# Altium schematic sheet Sheriff-Stern.SchDoc(Sheriff-Stern)
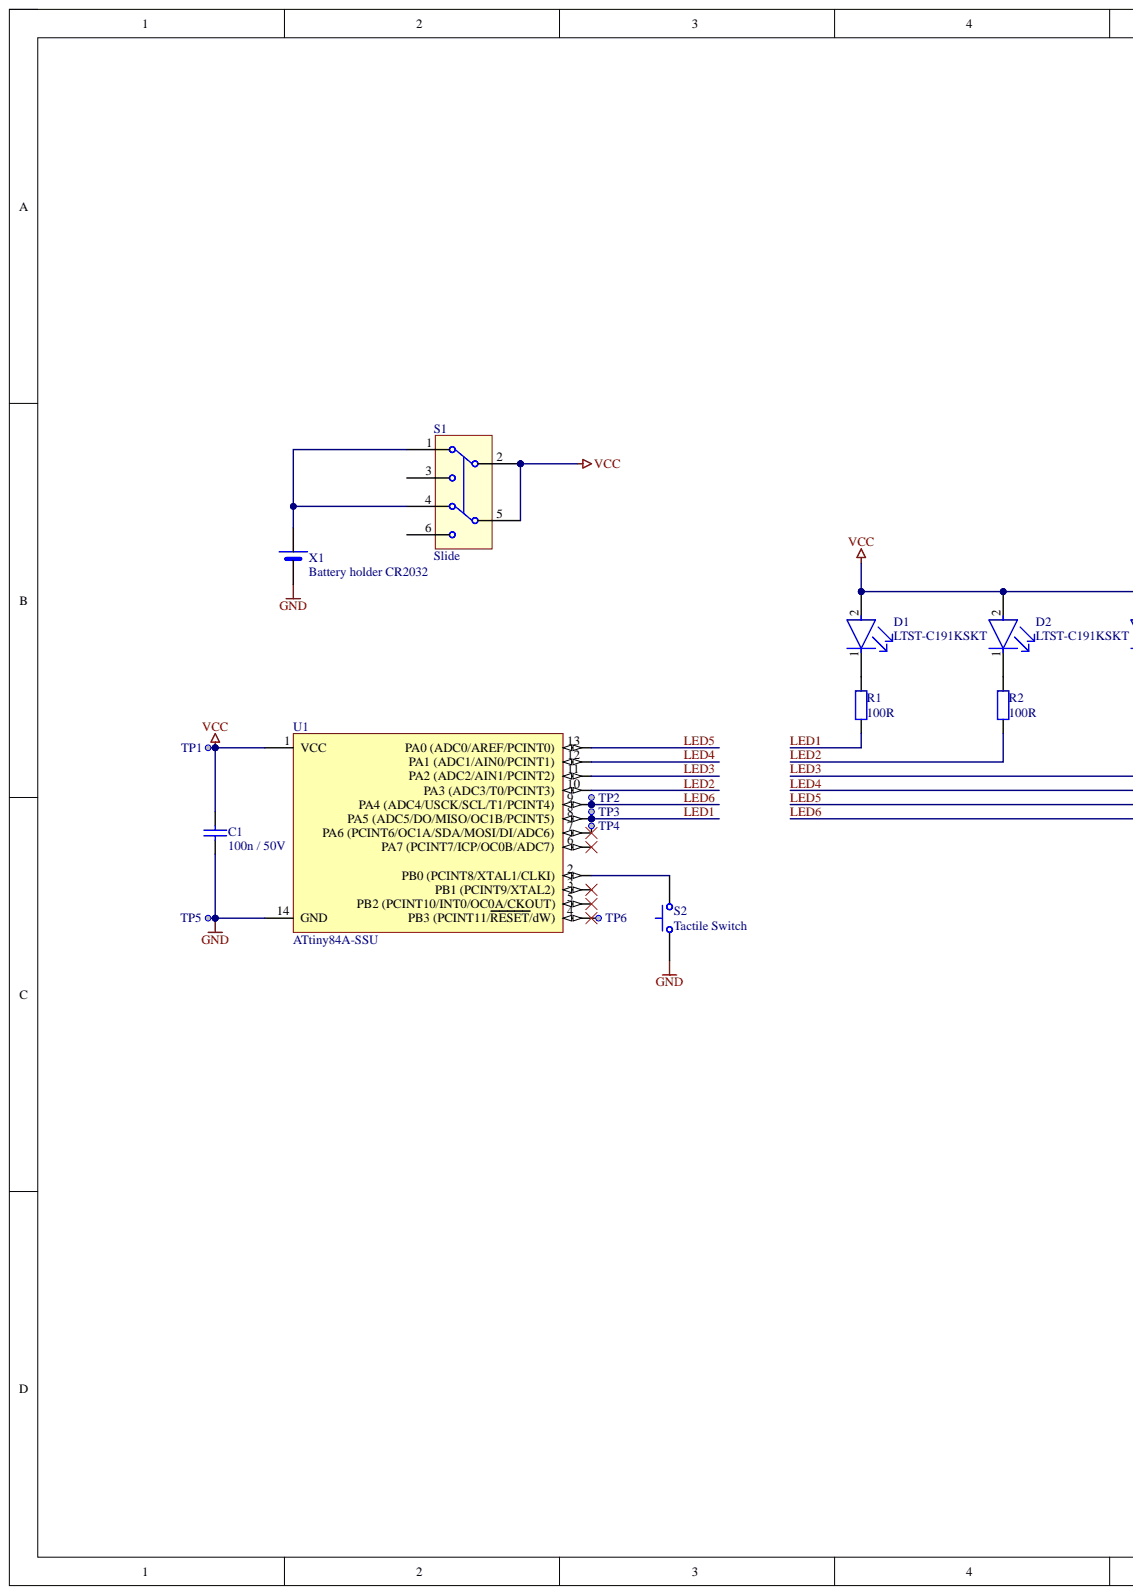
[diagram p0: Sheriff-Stern.SchDoc, left]
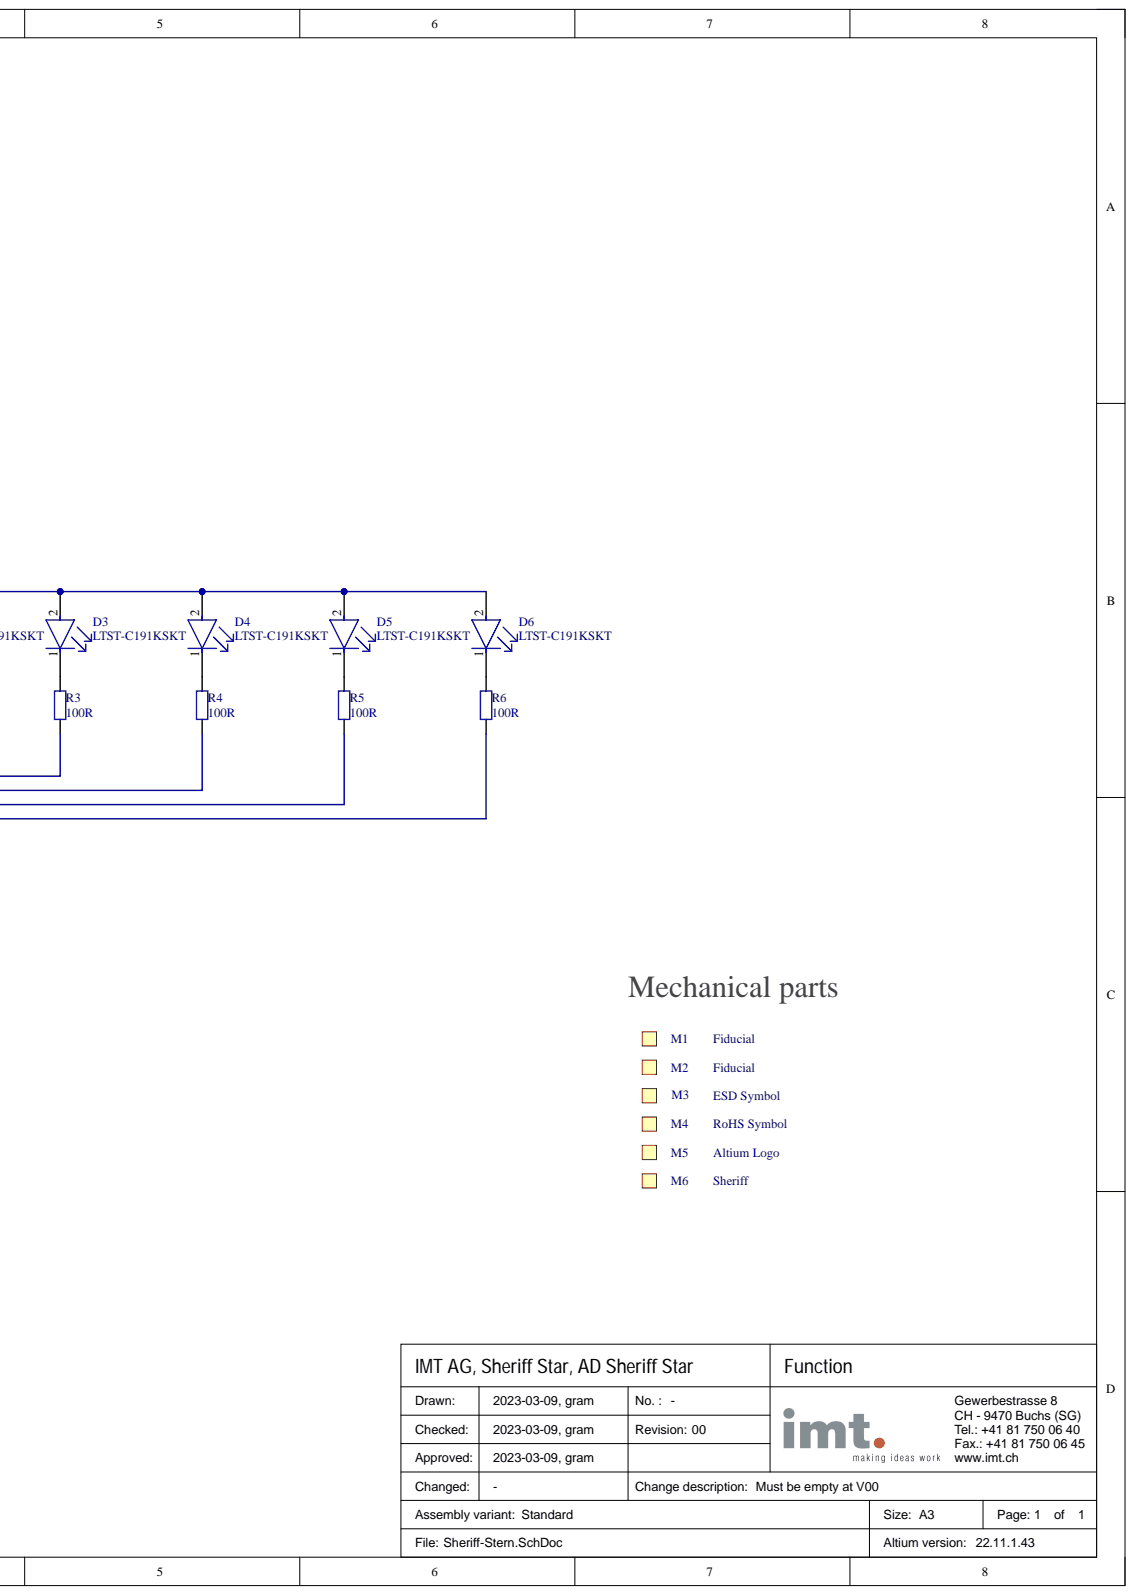
[diagram p1: Sheriff-Stern.SchDoc, right]

## Parts
C1  11011700  [SMT_CAP_0603_09H]  (value not drawn)
D1  LTST-C191KSKT  [FP-LTST-C191KSKT-MFG]
D2  LTST-C191KSKT  [FP-LTST-C191KSKT-MFG]
D3  LTST-C191KSKT  [FP-LTST-C191KSKT-MFG]
D4  LTST-C191KSKT  [FP-LTST-C191KSKT-MFG]
D5  LTST-C191KSKT  [FP-LTST-C191KSKT-MFG]
D6  LTST-C191KSKT  [FP-LTST-C191KSKT-MFG]
M1  11028600  [MECH_FIDUCIAL_2MM]  (value not drawn)  (no BOM)
M2  11028600  [MECH_FIDUCIAL_2MM]  (value not drawn)  (no BOM)
M3  11068200  [MECH_ESD_SYMBOL]  (value not drawn)  (no BOM)
M4  11031700  [MECH_ROHS_SYMBOL_SMALL_Overlay]  (value not drawn)  (no BOM)
M5  Altium Logo  [Altium-Logo]  (no BOM)
M6  Sheriff  [Sheriff]  (value not drawn)  (no BOM)
R1  Schema text: 100R  [SMT_RES_0402_04H]  (hidden comment: 11001400)
R2  Schema text: 100R  [SMT_RES_0402_04H]  (hidden comment: 11001400)
R3  Schema text: 100R  [SMT_RES_0402_04H]  (hidden comment: 11001400)
R4  Schema text: 100R  [SMT_RES_0402_04H]  (hidden comment: 11001400)
R5  Schema text: 100R  [SMT_RES_0402_04H]  (hidden comment: 11001400)
R6  Schema text: 100R  [SMT_RES_0402_04H]  (hidden comment: 11001400)
S1  Schema text: Slide  [SMT_CK_JS202011SCQN]  (hidden comment: 11152900)
S2  =Value  [SMT_ALPS_SKQM]
TP1  11039800  [MECH_TESTPOINT_0.8MM_NO_HOLE]  (value not drawn)  (no BOM)
TP2  11039800  [MECH_TESTPOINT_0.8MM_NO_HOLE]  (value not drawn)  (no BOM)
TP3  11039800  [MECH_TESTPOINT_0.8MM_NO_HOLE]  (value not drawn)  (no BOM)
TP4  11039800  [MECH_TESTPOINT_0.8MM_NO_HOLE]  (value not drawn)  (no BOM)
TP5  11039800  [MECH_TESTPOINT_0.8MM_NO_HOLE]  (value not drawn)  (no BOM)
TP6  11039800  [MECH_TESTPOINT_0.8MM_NO_HOLE]  (value not drawn)  (no BOM)
U1  ATtiny84A-SSU  [14S1_M]
X1  11130300  [SMT_RENATA_2032_SMTU2032-LF]  (value not drawn)

## Nets
GND: C1.2 S2.1 TP5.1 U1.14(GND) X1.2
VCC: C1.1 D1.2 D2.2 D3.2 D4.2 D5.2 D6.2 S1.2 S1.5 TP1.1 U1.1(VCC)
LED1: R1.2 TP3.1 U1.8(PA5_(ADC5/DO/MISO/OC1B/PCINT5))
LED2: R2.2 U1.10(PA3_(ADC3/T0/PCINT3))
LED3: R3.2 U1.11(PA2_(ADC2/AIN1/PCINT2))
LED4: R4.2 U1.12(PA1_(ADC1/AIN0/PCINT1))
LED5: R5.2 U1.13
LED6: R6.2 TP2.1 U1.9(PA4_(ADC4/USCK/SCL/T1/PCINT4))
NetD1_1: D1.1 R1.1
NetD2_1: D2.1 R2.1
NetD3_1: D3.1 R3.1
NetD4_1: D4.1 R4.1
NetD5_1: D5.1 R5.1
NetD6_1: D6.1 R6.1
NetS1_1: S1.1 S1.4 X1.1
NetS2_2: S2.2 U1.2(PB0_(PCINT8/XTAL1/CLKI))
NetTP4_1: TP4.1 U1.7(PA6_(PCINT6/OC1A/SDA/MOSI/DI/ADC6))
NetTP6_1: TP6.1 U1.4

Not connected: S1 3 6; U1 3(PB1_(PCINT9/XTAL2)) 5(PB2_(PCINT10/INT0/OC0A/CKOUT)) 6(PA7_(PCINT7/ICP/OC0B/ADC7))

## Library data of the parts
C1 = 11011700: Cap 100n 50V 10% X7R 0603; Manufacturer: Kemet; Manufacturer Part Number: C0603C104K5RAC; Schema text: 100n / 50V; Tmax: 125; Tmin: -55; Case: 0603; Component Kind: Standard; Mounting Technology: SMD; Supplier 1: Digi-Key; Supplier Part Number 1: 399-5089-1-ND; Library Name: Sheriff-Stern.SchLib
D1-D6 = CMP-22017-000025-1: LED YELLOW CLEAR SMD; Manufacturer: Vishay Lite-On; Dominant Wavelength: 597nm; Forward Current: 30mA; Forward Voltage: 2.4V; Lens Color: Colorless; Lens Style: Diffused; Luminous Intensity: 180mcd; Number of Elements: 1; Power Dissipation: 75mW; Reverse Voltage: 5V; Test Current: 20mA; Viewing Angle: 130°; Wavelength: 588nm; Case Code (Imperial): 0603; Case Code (Metric): 1608; Case/Package: 0603; Color: Yellow; Component Kind: Standard; Depth: 0.8mm; Height: 0.55mm; Illumination Color: Yellow; Lead Free: Lead Free; Length: 1.6mm; Lens Transparency: Clear; Manufacturer Part Number: LTST-C191KSKT; Max Operating Temperature: 85°C; Min Operating Temperature: -55°C; Mount: Surface Mount; Number of LEDs: 1; Number of Pins: 2; Package Quantity: 1; Power Rating: 75mW; Radiation Hardening: No; Width: 0.8mm; Library Name: Sheriff-Stern.SchLib
M1 M2 = 11028600: Fiducial; Manufacturer: _none; Manufacturer Part Number: _none; Schema text: Fiducial; Library Name: Sheriff-Stern.SchLib
M3 = 11068200: ESD Symbol; Manufacturer: _none; Manufacturer Part Number: -; Schema text: ESD Symbol; Library Name: Sheriff-Stern.SchLib
M4 = 11031700: RoHS Symbol; Manufacturer: _none; Manufacturer Part Number: -; Schema text: RoHS Symbol; Library Name: Sheriff-Stern.SchLib
M5 = Altium Logo: Manufacturer: _none; Description: Altium Logo; Manufacturer Part Number: -; Schema text: Altium Logo; Library Name: Sheriff-Stern.SchLib
M6 = Sheriff: Manufacturer: _none; Description: Sheriff; Manufacturer Part Number: -; Schema text: Sheriff; Library Name: Sheriff-Stern.SchLib
R1-R6 = 11001400: Res 100R 1% 63mW 100ppm 50V 0402; Manufacturer: Vishay; Manufacturer Part Number: CRCW0402100RFK; Tmax: 155; Tmin: -55; Case: 0402; Component Kind: Standard; Mounting Technology: SMD; Supplier 1: Digi-Key; Supplier Part Number 1: 541-3962-1-ND; Library Name: Sheriff-Stern.SchLib
S1 = 11152900: Switch SMT slide DPDT C&K JS202011SCQN 300mA 6V; Tmax: 85; Tmin: -40; Component Kind: Standard; Manufacturer: C&K; Manufacturer Part Number: JS202011SCQN; Mounting Technology: SMD; Supplier 1: Digi-Key; Supplier Part Number 1: 401-2002-1-ND; Library Name: Sheriff-Stern.SchLib
S2 = 11070700: Switch SMT Tactile 1xSPST-NO ALPS SKQMASE010 50mA/12VDC; Schema text: Tactile Switch; Tmax: 70; Tmin: -20; Component Kind: Standard; Manufacturer: Alps; Manufacturer Part Number: SKQMASE010; Mounting Technology: SMD; Supplier 1: Mouser; Supplier Part Number 1: 688-SKQMAS; Library Name: Sheriff-Stern.SchLib
TP1-TP6 = 11039800: Testpoint round 0.8mm w/o hole; Manufacturer: _none; Library Name: Sheriff-Stern.SchLib
U1 = CMP-0093-00274-1: 8-bit AVR Microcontroller,  20MHz, 1.8-5.5V, 8KB Flash, 512 Bytes EEPROM, 512 Bytes SRAM, 14-pin SOIC, Industrial Grade (-40°C to 85°C), Pb-Free; Manufacturer: Microchip; Code_IPC: SOIC127P600-14; Code_JEDEC: MS-012-AB; Component Kind: Standard; ComponentLink2Description: ATtiny24A/44A/84A Datasheet; ComponentLink2URL: http://www.atmel.com/dyn/resources/prod_documents/doc8183.pdf; ComponentLink3Description: ATtiny24A/44A/84A Summary; ComponentLink3URL: http://www.atmel.com/dyn/resources/prod_documents/8183S.pdf; DatasheetVersion: revD, Apr-2011; Manufacturer Part Number: ATTINY84A-SSU; PackageDescription: 14-Lead, 0.150â€ Wide Body, Plastic Gull Wing Small Outline Package (SOIC); PackageReference: 14S1; PackageVersion: revA, Feb-2002; Library Name: Sheriff-Stern.SchLib
X1 = 11130300: Con SMT Renata SMTU Battery holder CR2032; Manufacturer Part Number: SMTU2032-LF; Component Kind: Standard; Manufacturer: Renata; Mounting Technology: SMD; Schema text: Battery holder CR2032; Supplier 1: Farnell; Supplier Part Number 1: 1216354; Library Name: Sheriff-Stern.SchLib
